# Altium schematic sheet DPAdapter.SchDoc
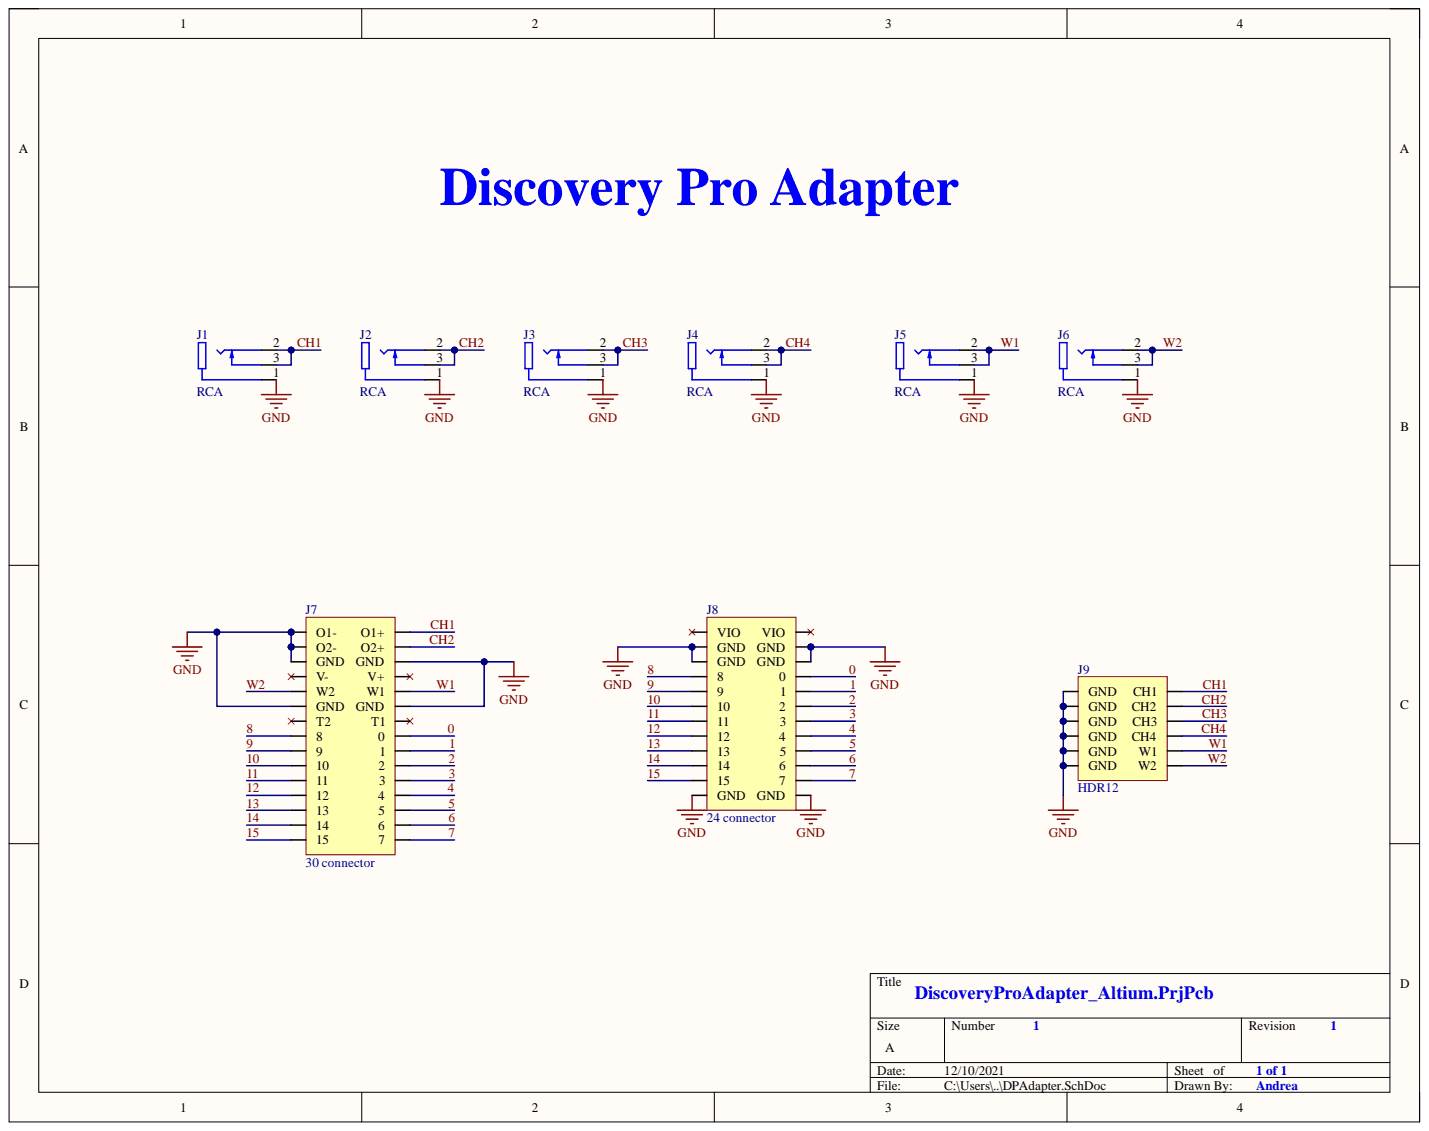
[diagram p0: DPAdapter.SchDoc]

## Parts
J1  RCA  [PJRAN1X1U]
J2  RCA  [PJRAN1X1U]
J3  RCA  [PJRAN1X1U]
J4  RCA  [PJRAN1X1U]
J5  RCA  [PJRAN1X1U]
J6  RCA  [PJRAN1X1U]
J7  30 connector  [AWHW_30_254]
J8  24 connector  [AWHW_24_254]
J9  HDR12  [HDR12_2.54]

## Nets
GND: J1.1 J2.1 J3.1 J4.1 J5.1 J6.1 J7.3(GND) J7.6(GND) J7.16(O1-) J7.17(O2-) J7.18(GND) J7.21(GND) J8.2(GND) J8.3(GND) J8.12(GND) J8.14(GND) J8.15(GND) J8.24(GND) J9.7(GND) J9.8(GND) J9.9(GND) J9.10(GND) J9.11(GND) J9.12(GND)
0: J7.8 J8.4
1: J7.9 J8.5
2: J7.10 J8.6
3: J7.11 J8.7
4: J7.12 J8.8
5: J7.13 J8.9
6: J7.14 J8.10
7: J7.15 J8.11
8: J7.23 J8.16
9: J7.24 J8.17
10: J7.25 J8.18
11: J7.26 J8.19
12: J7.27 J8.20
13: J7.28 J8.21
14: J7.29 J8.22
15: J7.30 J8.23
CH1: J1.2 J1.3 J7.1(O1+) J9.1(CH1)
CH2: J2.2 J2.3 J7.2(O2+) J9.2(CH2)
CH3: J3.2 J3.3 J9.3(CH3)
CH4: J4.2 J4.3 J9.4(CH4)
W1: J5.2 J5.3 J7.5(W1) J9.5(W1)
W2: J6.2 J6.3 J7.20(W2) J9.6(W2)

Not connected: J7 4(V+) 7(T1) 19(V-) 22(T2); J8 1(VIO) 13(VIO)

## Library data of the parts
J1-J6 = PJRAN1X1U04X: 3.20mm ID, 9.00mm OD (RCA) Phono (RCA) Jack Mono Connector Solder; MF: Switchcraft Inc.; Availability: In Stock; Check_prices: https://www.snapeda.com/parts/PJRAN1X1U04X/Switchcraft%20Inc./view-part/64730/?ref=eda; Component Kind: Standard; Library Name: DPAdapter.SchLib; MP: PJRAN1X1U04X; Package: None; Price: None; SnapEDA_Link: https://www.snapeda.com/parts/PJRAN1X1U04X/Switchcraft%20Inc./view-part/64730/?ref=snap
J7 = DIGILENT_RIBBON: Component Kind: Standard; Library Name: DPAdapter.SchLib
J8 = DIGILENT_RIBBON_SMALL: Component Kind: Standard; Library Name: DPAdapter.SchLib
J9 = HDR_12_2.54: Component Kind: Standard; Library Name: DPAdapter.SchLib
